# Altium schematic sheet STM32-Flasher.SchDoc
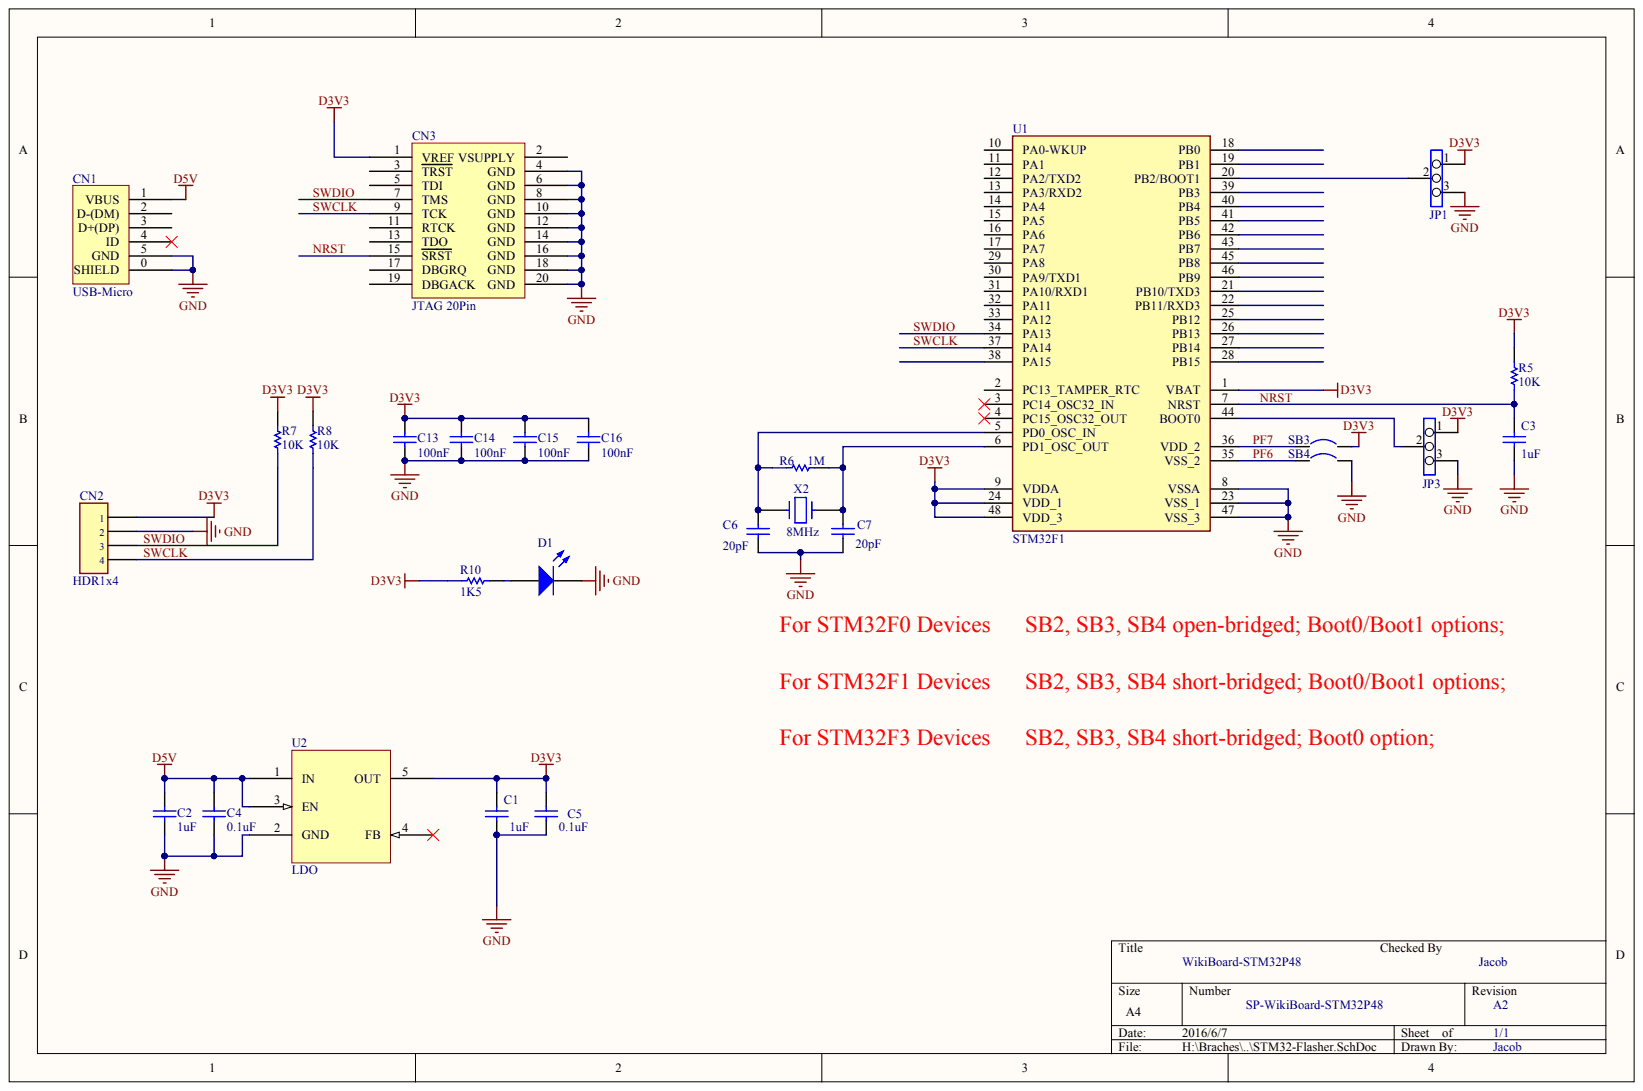
[diagram p0: STM32-Flasher.SchDoc]

## Parts
C1  value 1uF  (hidden comment: Cap)
C2  value 1uF  (hidden comment: Cap)
C3  value 1uF  (hidden comment: Cap)
C4  value 0.1uF  (hidden comment: Cap)
C5  value 0.1uF  (hidden comment: Cap)
C6  value 20pF  (hidden comment: Cap)
C7  value 20pF  (hidden comment: Cap)
C13  value 100nF  (hidden comment: Cap)
C14  value 100nF  (hidden comment: Cap)
C15  value 100nF  (hidden comment: Cap)
C16  value 100nF  (hidden comment: Cap)
CN1  USB-Micro
CN2  HDR1x4
CN3  JTAG 20Pin
D1  LED1  (value not drawn)
JP1  Jumper3  (value not drawn)
JP3  Jumper3  (value not drawn)
R5  10K
R6  1M
R7  10K
R8  10K
R10  1K5
SB3  Circuit Breaker  (value not drawn)
SB4  Circuit Breaker  (value not drawn)
U1  STM32F1
U2  LDO
X2  8MHz

## Nets
D3V3: C1.2 C5.2 C13.2 C14.2 C15.2 C16.2 CN2.1 CN3.1(VREF) JP1.1 JP3.1 R5.2 R7.2 R8.2 R10.1 SB3.2 U1.1(VBAT) U1.9(VDDA) U1.24(VDD_1) U1.48(VDD_3) U2.5(OUT)
D5V: C2.2 C4.2 CN1.1(VBUS) U2.1(IN) U2.3(EN)
GND: C1.1 C2.1 C3.1 C4.1 C5.1 C6.1 C7.1 C13.1 C14.1 C15.1 C16.1 CN1.0(SHIELD) CN1.5(GND) CN2.2 CN3.4(GND) CN3.6(GND) CN3.8(GND) CN3.10(GND) CN3.12(GND) CN3.14(GND) CN3.16(GND) CN3.18(GND) CN3.20(GND) D1.2 JP1.3 JP3.3 SB4.2 U1.8(VSSA) U1.23(VSS_1) U1.47(VSS_3) U2.2(GND)
NRST: C3.2 CN3.15(SRST) R5.1 U1.7(NRST)
PF6: SB4.1 U1.35(VSS_2)
PF7: SB3.1 U1.36(VDD_2)
SWCLK: CN2.4 CN3.9(TCK) R8.1 U1.37(PA14)
SWDIO: CN2.3 CN3.7(TMS) R7.1 U1.34(PA13)
NetC6_2: C6.2 R6.1 U1.5(PD0_OSC_IN) X2.2
NetC7_2: C7.2 R6.2 U1.6(PD1_OSC_OUT) X2.1
NetCN1_2: CN1.2(D-(DM))
NetCN1_3: CN1.3(D+(DP))
NetCN1_4: CN1.4(ID)
NetCN3_2: CN3.2(VSUPPLY)
NetD1_1: D1.1 R10.2
NetJP1_2: JP1.2 U1.20(PB2/BOOT1)
NetJP3_2: JP3.2 U1.44(BOOT0)
NetU1_2: U1.2(PC13_TAMPER_RTC)
NetU1_10: U1.10(PA0-WKUP)
NetU1_12: U1.12(PA2/TXD2)
NetU1_13: U1.13(PA3/RXD2)
NetU1_14: U1.14(PA4)
NetU1_15: U1.15(PA5)
NetU1_18: U1.18(PB0)
NetU1_19: U1.19(PB1)
NetU1_27: U1.27(PB14)
NetU1_28: U1.28(PB15)
NetU2_4: U2.4(FB)

Not connected: CN3 3(TRST) 5(TDI) 11(RTCK) 13(TDO) 17(DBGRQ) 19(DBGACK); U1 3(PC14_OSC32_IN) 4(PC15_OSC32_OUT) 11(PA1) 16(PA6) 17(PA7) 21(PB10/TXD3) 22(PB11/RXD3) 25(PB12) 26(PB13) 29(PA8) 30(PA9/TXD1) 31(PA10/RXD1) 32(PA11) 33(PA12) 38(PA15) 39(PB3) 40(PB4) 41(PB5) 42(PB6) 43(PB7) 45(PB8) 46(PB9)

## Library data of the parts
SB3 SB4 = (no symbol name): Solder: YES; Published: 24-Mar-1999; Revision: July-2002: Re-released for DXP Platform.; Publisher: Altium Limited
C1-C7 C13-C16 CN1-CN3 D1 JP1 JP3 R5-R8 R10 U1 U2 X2 = (no symbol name)
  C1-C7 C13-C16: Published: 8-Jun-2000; LatestRevisionDate: 17-Jul-2002; LatestRevisionNote: Re-released for DXP Platform.; PackageReference: RAD-0.3
  D1: Published: 8-Jun-2000; LatestRevisionDate: 08-Jul-2005; LatestRevisionNote: Mech Layer 1 removed.; PackageReference: LED-1
  R5-R8 R10: Design Item Id: RES-50R-1%-0805
  C1-C7 C13-C16 D1: Publisher: Altium Limited
